# Altium schematic sheet ETRX_Shield.SchDoc
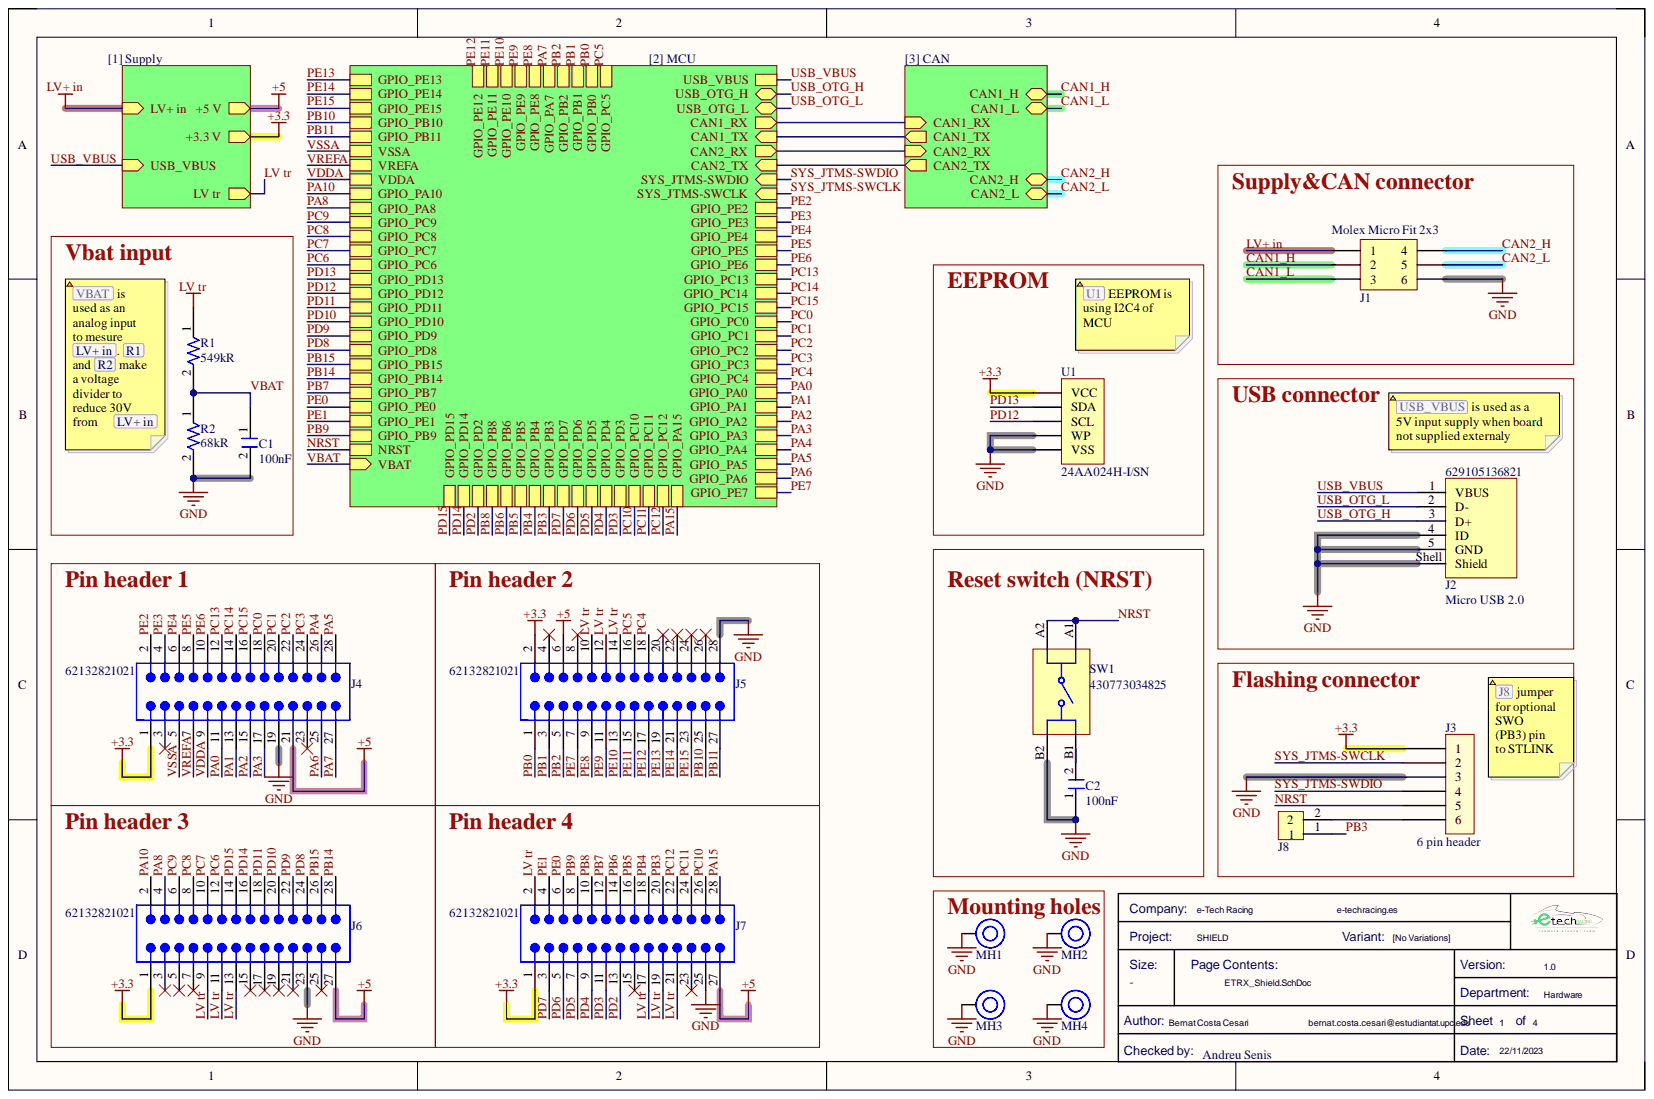
[diagram p0: ETRX_Shield.SchDoc]

## Parts
C1  Capacitance: 100nF  [C0805FNPC-R]  (hidden comment: 885012207098)
C2  Capacitance: 100nF  [C1206FNPC-RW]  (hidden comment: 885012208058)
J1  Molex Micro Fit 2x3  Manufacturer: Molex  [J_MicroFit_2x3_V]
J2  629105136821  Application: Micro USB 2.0  [629105136821]
J3  6 pin header  [61300611121]
J4  62132821021  [62132821021]
J5  62132821021  [62132821021]
J6  62132821021  [62132821021]
J7  62132821021  [62132821021]
J8  61300211121  [J_Pinheader_1x2]  (value not drawn)
MH1  Mounting_Hole_M3  [Mounting_Hole_M3]  (value not drawn)
MH2  Mounting_Hole_M3  [Mounting_Hole_M3]  (value not drawn)
MH3  Mounting_Hole_M3  [Mounting_Hole_M3]  (value not drawn)
MH4  Mounting_Hole_M3  [Mounting_Hole_M3]  (value not drawn)
R1  549kR  [R0805FTK]
R2  Resistance: 68kR  [R1206FTK-W]  (hidden comment: CR1206AFX-6802EAS)
SW1  430773034825  [WS-TASV_L6W6H3.4_4307x30348xx]
U1  24AA024H-I/SN  [SOIC, 8-Leads 1,27mm]

## Nets
+3.3: J3.1 J4.1 J5.2 J6.1 J7.1 U1.8(VCC)
+5: J4.21 J5.6 J6.27 J7.27
GND: C1.2 C2.1 J1.6 J2.4(ID) J2.5(GND) J2.Shell(Shield) J3.3 J4.19 J5.28 J6.23 J7.25 MH1.1 MH2.1 MH3.1 MH4.1 R2.2 SW1.B2 U1.4(VSS) U1.7(WP)
CAN1_H: J1.2
CAN1_L: J1.3
CAN2_H: J1.4
CAN2_L: J1.5
LV tr: J5.10 J5.12 J5.14 J6.9 J6.11 J6.13 J7.2 J7.17 J7.19 J7.21 R1.1
LV+ in: J1.1
NRST: J3.5 SW1.A1 SW1.A2
PA0: J4.11
PA1: J4.13
PA2: J4.15
PA3: J4.17
PA4: J4.26
PA5: J4.28
PA6: J4.25
PA7: J4.27
PA8: J6.4
PA10: J6.2
PA15: J7.28
PB0: J5.1
PB1: J5.3
PB2: J5.5
PB3: J7.20 J8.1
PB4: J7.18
PB5: J7.16
PB6: J7.14
PB7: J7.12
PB8: J7.10
PB9: J7.8
PB10: J5.25
PB11: J5.27
PB14: J6.28
PB15: J6.26
PC0: J4.18
PC1: J4.20
PC2: J4.22
PC3: J4.24
PC4: J5.18
PC5: J5.16
PC6: J6.12
PC7: J6.10
PC8: J6.8
PC9: J6.6
PC10: J7.26
PC11: J7.24
PC12: J7.22
PC13: J4.12
PC14: J4.14
PC15: J4.16
PD2: J7.13
PD3: J7.11
PD4: J7.9
PD5: J7.7
PD6: J7.5
PD7: J7.3
PD8: J6.24
PD9: J6.22
PD10: J6.20
PD11: J6.18
PD12: U1.6(SCL)
PD13: U1.5(SDA)
PD14: J6.16
PD15: J6.14
PE0: J7.6
PE1: J7.4
PE2: J4.2
PE3: J4.4
PE4: J4.6
PE5: J4.8
PE6: J4.10
PE7: J5.7
PE8: J5.9
PE9: J5.11
PE10: J5.13
PE11: J5.15
PE12: J5.17
PE13: J5.19
PE14: J5.21
PE15: J5.23
SYS_JTMS-SWCLK: J3.2
SYS_JTMS-SWDIO: J3.4
USB_OTG_H: J2.3(D+)
USB_OTG_L: J2.2(D-)
USB_VBUS: J2.1(VBUS)
VBAT: C1.1 R1.2 R2.1
VDDA: J4.9
VREFA: J4.7
VSSA: J4.5
NetC2_2: C2.2 SW1.B1
NetJ3_6: J3.6 J8.2
NetJ4_3: J4.3
NetJ4_23: J4.23
NetJ5_4: J5.4
NetJ5_8: J5.8
NetJ5_20: J5.20
NetJ5_22: J5.22
NetJ5_24: J5.24
NetJ5_26: J5.26
NetJ6_3: J6.3
NetJ6_5: J6.5
NetJ6_7: J6.7
NetJ6_15: J6.15
NetJ6_17: J6.17
NetJ6_19: J6.19
NetJ6_21: J6.21
NetJ6_25: J6.25
NetJ7_15: J7.15
NetJ7_23: J7.23
CAN1_RX:
CAN1_TX:
CAN2_RX:
CAN2_TX:

## Library data of the parts
C1 = C100n-50V-0805FNPC-X7R: Cap, 0.1ΜF, 50V, 10%, X7R, 0805; Manufacturer 1: Wurth Electronics; .Creator: DR; Case Code (Imperial): 0805; Case Code (Metric): 2012; Case/Package: 0805; Category: Ceramic Capacitors; Component Kind: Standard; ComponentLink1Description: http://www.farnell.com/datasheets/1958498.pdf?src-supplier=Farnell; ComponentLink1URL: http://www.farnell.com/datasheets/1958498.pdf?src-supplier=Farnell; ComponentLink2Description: http://www.farnell.com/datasheets/1958498.pdf?src-supplier=Element14; ComponentLink2URL: http://www.farnell.com/datasheets/1958498.pdf?src-supplier=Element14; ComponentLink3Description: https://katalog.we-online.de/pbs/datasheet/885012207098.pdf?src-supplier=Digi-Key; ComponentLink3URL: https://katalog.we-online.de/pbs/datasheet/885012207098.pdf?src-supplier=Digi-Key; ComponentLink4Description: http://www.farnell.com/datasheets/1958498.pdf?src-supplier=Newark; ComponentLink4URL: http://www.farnell.com/datasheets/1958498.pdf?src-supplier=Newark; Dielectric: X7R; Length: 0.079inch; Library Name: Passives.SchLib; Manufacturer Part Number 1: 885012207098; Max Operating Temperature: 125°C; Min Operating Temperature: -55°C; Mount: Surface Mount; Packaging: Cut Tape; Pricing 1: 100=0,10200, 500=0,07100, 2000=0,06100 (GBP); REACH SVHC: Unknown; RoHS: Yes; RoHS Compliant: Yes; Stock 1: 8000; Supplier 1: RSComponents; Supplier Part Number 1: 1813493; Thickness: 0.035inch; Tolerance: 10%; Voltage Rating: 50V; Voltage Rating (DC): 50V; Width: 0.049inch
C2 = C100n-25V-1206FNPC-X7R: Cap Cer 0.1UF 25V X7R 1206; Manufacturer: Wurth Electronics; .Creator: DO; Tolerance: 10%; Case Code (Imperial): 1206; Case Code (Metric): 3216; Case/Package: 1206; Category: Capacitor; Component Kind: Standard; ComponentLink1Description: Datasheet; ComponentLink1URL: https://www.we-online.com/catalog/datasheet/885012208058.pdf; ComponentLink2Description: Where buy it; ComponentLink2URL: https://www.we-online.com/catalog/en/WCAP-CSGP-25VDC#885012208058; ComponentLink3Description: https://katalog.we-online.de/pbs/datasheet/885012208058.pdf?src-supplier=Digi-Key; ComponentLink3URL: https://katalog.we-online.de/pbs/datasheet/885012208058.pdf?src-supplier=Digi-Key; Length: 0.126inch; Library Name: Passives.SchLib; Manufacturer Part Number: 885012208058; Max Operating Temperature: 125°C; Min Operating Temperature: -55°C; Mount: Surface Mount; Packaging: Tape and Reel; Pricing 1: 1=0,11000, 10=0,10100, 100=0,09100, 500=0,08000 (USD); RoHS: Yes; RoHS Compliant: Yes; Stock 1: 4375; Supplier 1: Digi-Key; Supplier Part Number 1: 732-8109-1-ND; Thickness: 0.035inch; Voltage Rating: 25V; Width: 0.063inch
J1 = J_MicroFit_2x3_V: Micro fit Header, 2 Row, Vertical, Polarizing Peg, 6 Pin, Tin Plate, SMT/Glow Wire, Kinked Leads; Manufacturer Part Number: 43045-0612; .Creator: DO; Category: Headers and Wire Housings; Component Kind: Standard; ComponentLink1Description: Datasheet; ComponentLink1URL: https://www.molex.com/pdm_docs/sd/430450612_sd.pdf; ComponentLink2Description: https://datasheet.ciiva.com/11748/0900766b800bd7d2-11748707.pdf?src-supplier=RSComponents; ComponentLink2URL: https://datasheet.ciiva.com/11748/0900766b800bd7d2-11748707.pdf?src-supplier=RSComponents; ComponentLink3Description: https://datasheet.ciiva.com/19462/883464-19462371.pdf?src-supplier=Jameco; ComponentLink3URL: https://datasheet.ciiva.com/19462/883464-19462371.pdf?src-supplier=Jameco; ComponentLink4Description: https://datasheet.ciiva.com/30194/128550430450612-pcb-headers-30194512.pdf?src-supplier=Onlinecomponents.com; ComponentLink4URL: https://datasheet.ciiva.com/30194/128550430450612-pcb-headers-30194512.pdf?src-supplier=Onlinecomponents.com; ComponentLink5Description: https://datasheet.ciiva.com/9468/0430450612-pcb-headers-9468492.pdf?src-supplier=Avnet; ComponentLink5URL: https://datasheet.ciiva.com/9468/0430450612-pcb-headers-9468492.pdf?src-supplier=Avnet; ComponentLink8Description: Where buy it; ComponentLink8URL: https://www.molex.com/molex/products/part-detail/pcb_headers/0430450612; Library Name: Electromechanical.SchLib; Pricing 1: 5=1,45500, 100=1,26700, 375=1,08900, 1500=0,90900, 3000=0,72900 (USD); RoHS: Yes; Stock 1: 2635; Supplier 1: RSComponents; Supplier Part Number 1: 2332898
J2 = 629105136821: WR-COM Micro USB 2.0 SMT Type B Horizontal 5 Contacts; Manufacturer: Wurth Elektronik; Gender: Receptacle; Interface Type: Type B; Match Code: WR-USB; Number of Ports: 1; Rated Current: 1A; Working Voltage: 30V (AC); Category: Input/Output Connectors; Component Kind: Standard; ComponentLink1Description: Website Link; ComponentLink1URL: https://www.we-online.com/redexpert/article/629105136821?ad; ComponentLink2Description: Datasheet Link; ComponentLink2URL: https://www.we-online.com/redexpert/spec/629105136821?ad; Library Name: WR-USB.IntLib; Manufacturer Part Number: 629105136821; Mount: Surface Mount; Number of Pins: 5; Operating Temperature Max: 105°C; Operating Temperature Min: -40°C; Orientation: Horizontal; Packaging: Tape and Reel
J4-J7 = 62132821021: WR-PHD Pin Header, SMT, Vertical, pitch 1.27mm, 2 Row, 28P; Manufacturer: Wurth Elektronik; Contact Resistance: 20mΩ; Rated Current: 1A; Withstanding Voltage: 500V; Working Voltage: 100V; Category: Board-to-Board Connectors; Component Kind: Standard; ComponentLink1Description: Website Link; ComponentLink1URL: https://www.we-online.com/redexpert/article/62132821021?ad; ComponentLink2Description: Datasheet Link; ComponentLink2URL: https://www.we-online.com/redexpert/spec/62132821021?ad; Gender: Pin Header; Library Name: Electromechanical.SchLib; Manufacturer Part Number: 62132821021; Match Code: WR-PHD; Mount: Surface Mount; Number of Pins: 28; Number of Rows: 2; Operating Temperature Max: 105°C; Operating Temperature Min: -40°C; Orientation: Vertical; Packaging: Tape and Reel; Pitch: 1.27mm
J8 = J_Jumper: CONN HEADER 2 POS 2.54; Manufacturer: Molex; .Creator: GP; Category type: PCB-PCB; Connections: 1x2; Connector Type: Male Pin; Current Rating: 3A; Gender: Male; Maximum current: 3 A; Maximum voltage: 250 V AC; Number of Contacts: 2; Pitch: 0.1inch; Product name: Pin Header; Temperature rating: -40° to +105°C; Voltage Rating: 250V; Application: Shortcircuit; Category: Connector; Color: Black; Component Kind: Standard; ComponentLink1Description: Datasheet; ComponentLink1URL: https://www.we-online.com/catalog/datasheet/61300211121.pdf; ComponentLink2Description: Where buy it; ComponentLink2URL: https://www.we-online.com/catalog/en/PHD_2_54_THT_PIN_HEADER_6130XX11121?sq=61300211121&sp=https%3A%2F%2Fwww.we-online.com%2Fweb%2Fen%2Fwuerth_elektronik%2Fsearchpage.php%3Fsearch%3D61300211121#61300211121; ComponentLink3Description: https://datasheet.ciiva.com/13619/6130xx11121-48870-13619345.pdf?src-supplier=Mouser; ComponentLink3URL: https://datasheet.ciiva.com/13619/6130xx11121-48870-13619345.pdf?src-supplier=Mouser; Contact Gender: Male; Contact Material: Copper Alloy,Copper; Contact Mating Length: 0.236inch; Contact Plating: Gold; Flammability: 94V-0; Housing Material: PBT; Lead Free: Lead Free; Library Name: Electromechanical.SchLib; Manufacturer part number: 61300211121; Max Operating Temperature: 125°C; Min Operating Temperature: -40°C; Mount: Through hole; Number of Positions: 2; Number of Rows: 1; Orientation: Vertical; Packaging: Bulk; Pricing 1: 5=0,14100, 50=0,09700, 100=0,07800, 200=0,07300, 500=0,06800 (USD); REACH SVHC: Unknown; Stock 1: 1855; Supplier: Würth Elektronik; Supplier 1: RSComponents; Supplier Part Number 1: 8281581; Termination: Through Hole
R1 = R549k - 0805: Component Kind: Standard; Library Name: Passives.SchLib
R2 = R68k-1206FTK: Resistor, AS 1206 68k1% 250mW TC100; Manufacturer: Bourns; .Creator: DO; Category: Chip SMD Resistors; Component Kind: Standard; ComponentLink1Description: Where buy it; ComponentLink1URL: https://www.mouser.es/ProductDetail/Bourns/CR1206AFX-6802EAS?qs=PzGy0jfpSMtMA5jyzS7M7w%3D%3D; ComponentLink2Description: Datasheet; ComponentLink2URL: https://www.mouser.es/datasheet/2/54/cr_a_as-1858644.pdf; Library Name: Passives.SchLib; Manufacturer Part Number: CR1206AFX-6802EAS; Pricing 1: 1=0,01100, 25=0,01100, 50=0,01100, 100=0,01100, 250=0,01100, 500=0,01100 (USD); RoHS: Yes; Stock 1: 4700; Supplier 1: Newark; Supplier Part Number 1: 16AH0632
SW1 = 430773034825: WS-TASV J-Hook SMT Hermetic Tact Switch, L6 x W6 x H3.4mm, 250g, SPST-NO, 12V, 50mA; Manufacturer: Wurth Elektronik; Washable: Yes; Category: Tact Switches; Circuit: SPST-NO; Component Kind: Standard; ComponentLink1Description: Website Link; ComponentLink1URL: https://www.we-online.com/redexpert/article/430773034825?ad; ComponentLink2Description: Datasheet Link; ComponentLink2URL: https://www.we-online.com/redexpert/spec/430773034825?ad; Contact Material: Stainless Steel; Contact Plating: Silver; Height: 3.4mm; Length: 6mm; Manufacturer Part Number: 430773034825; Match Code: WS-TASV; Mount: Surface Mount; Operating Temperature Max: 85°C; Operating Temperature Min: -40°C; Operation Force: 250g; Packaging: Tape and Reel; Rated Current: 50mA; Rated Voltage (DC): 12V; Terminal Material: Copper Alloy; Terminal Plating: Silver; Width: 6mm; Library Name: Altium_WS-SW bo.IntLib
U1 = EEPROM 24AA02I2C 2K Microchip: 24AA02  2Kb I2C compatible 2-wire Serial EEPROM; Component Kind: Standard; ComponentLink1Description: Datasheet; ComponentLink1URL: https://ww1.microchip.com/downloads/aemDocuments/documents/MPD/ProductDocuments/DataSheets/24AA02-24LC02B-24FC02-2K-I2C-Serial-EEPROM-20001709N.pdf; Library Name: Integrated circuits.SchLib; Supplier 1: Arrow Electronics; Supplier Part Number 1: 24AA02-I/SN
J3 = 6 pin header: Component Kind: Standard; Library Name: CAN Transceiver.SchLib
MH1-MH4 = Mounting_Hole_M3: Component Kind: Standard; Library Name: Electromechanical.SchLib
